# Altium schematic sheet LED_Drivers.SchDoc(LED_Drivers)
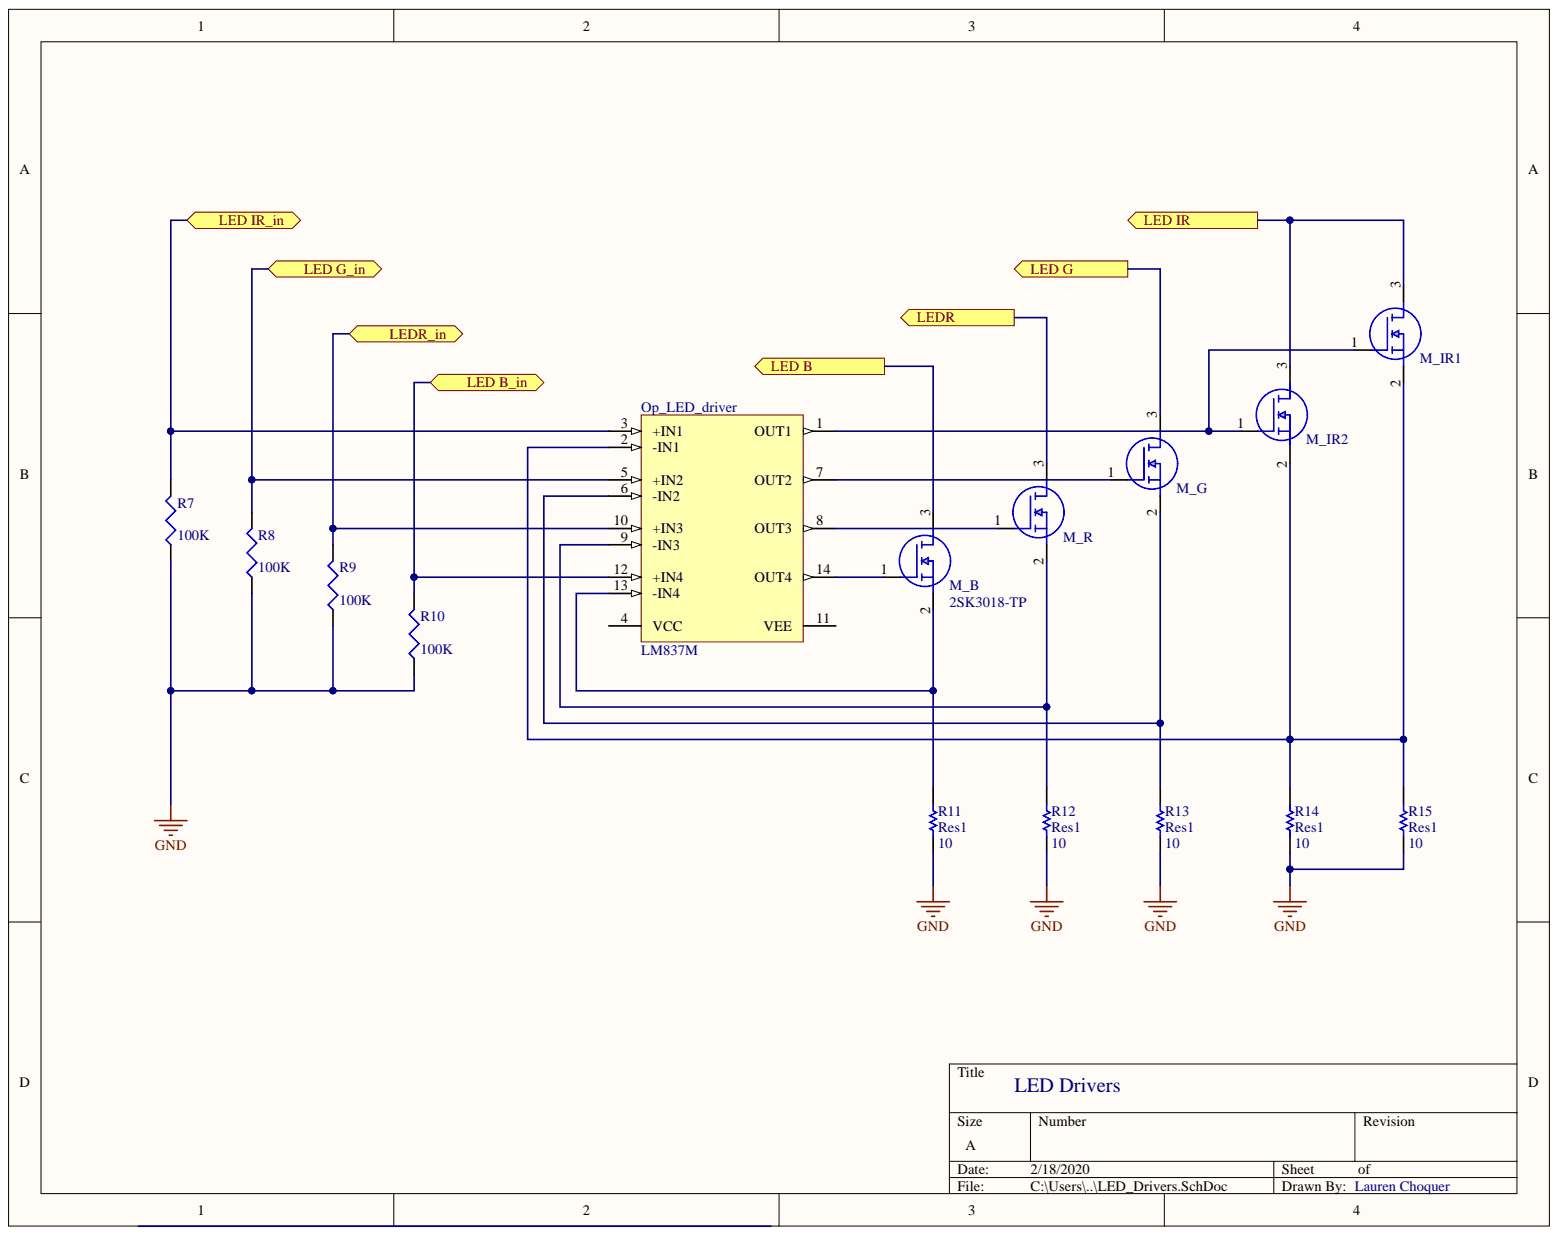
[diagram p0: LED_Drivers.SchDoc]

## Parts
M_B  2SK3018-TP  [2SK3018TP]
M_G  2SK3018-TP  [2SK3018TP]
M_IR1  2SK3018-TP  [2SK3018TP]
M_IR2  2SK3018-TP  [2SK3018TP]
M_R  2SK3018-TP  [2SK3018TP]
Op_LED_driver  LM837M  [D0014A_M]
R7  value 100K  [J1-0603]  (hidden comment: Res3)
R8  value 100K  [J1-0603]  (hidden comment: Res3)
R9  value 100K  [J1-0603]  (hidden comment: Res3)
R10  value 100K  [J1-0603]  (hidden comment: Res3)
R11  Res1  value 10  [AXIAL-0.3]
R12  Res1  value 10  [AXIAL-0.3]
R13  Res1  value 10  [AXIAL-0.3]
R14  Res1  value 10  [AXIAL-0.3]
R15  Res1  value 10  [AXIAL-0.3]

## Ports
LED B, LED B_in, LED G, LED G_in, LED IR, LED IR_in, LEDR, LEDR_in

## Nets
GND: R7.1 R8.1 R9.1 R10.1 R11.1 R12.1 R13.1 R14.1 R15.1
LED B: M_B.3 ; port LED B
LED B_in: Op_LED_driver.12(+IN4) R10.2 ; port LED B_in
LED G: M_G.3 ; port LED G
LED G_in: Op_LED_driver.5(+IN2) R8.2 ; port LED G_in
LED IR: M_IR1.3 M_IR2.3 ; port LED IR
LED IR_in: Op_LED_driver.3(+IN1) R7.2 ; port LED IR_in
LEDR: M_R.3 ; port LEDR
LEDR_in: Op_LED_driver.10(+IN3) R9.2 ; port LEDR_in
NetM_B_1: M_B.1 Op_LED_driver.14(OUT4)
NetM_B_2: M_B.2 Op_LED_driver.13(-IN4) R11.2
NetM_G_1: M_G.1 Op_LED_driver.7(OUT2)
NetM_G_2: M_G.2 Op_LED_driver.6(-IN2) R13.2
NetM_IR1_1: M_IR1.1 M_IR2.1 Op_LED_driver.1(OUT1)
NetM_IR1_2: M_IR1.2 M_IR2.2 Op_LED_driver.2(-IN1) R14.2 R15.2
NetM_R_1: M_R.1 Op_LED_driver.8(OUT3)
NetM_R_2: M_R.2 Op_LED_driver.9(-IN3) R12.2
N00028:

Not connected: Op_LED_driver 4(VCC) 11(VEE)

## Library data of the parts
M_B M_G M_IR1 M_IR2 M_R = 2SK3018-TP: MOSFET N-Channel MOSFET, SOT-323 package; Manufacturer_Name: Micro Commercial Components (MCC); Geometry.Height: 1.1mm; Mouser Price/Stock: https://www.mouser.com/Search/Refine.aspx?Keyword=833-2SK3018-TP; Mouser Part Number: 833-2SK3018-TP; Manufacturer_Part_Number: 2SK3018-TP; Library Name: 2SK3018-TP.SchLib; Datasheet Link: https://www.mccsemi.com/pdf/Products/2SK3018(SOT-323).pdf; Component Kind: Standard
Op_LED_driver = CMP-0057-00361-3: Low Noise Quad Operational Amplifier, 14-pin Narrow SOIC; Manufacturer: Texas Instruments; PowerWise: Y; PackageTopMarking: NSUZXYTTE#*LM837M; CompType: Audio IC; RoHS: N; PinCount: 14; PackageReference: D0014A; PackageDescription: Molded Package S.O., .150in Wide, 14 Lead; Library Name: Altium Content Vault; ComponentLink1URL: http://www.ti.com/general/docs/lit/getliterature.tsp?genericPartNumber=LM837&fileType=pdf; ComponentLink1Description: Datasheet URL; Component Kind: Standard; Code_JEDEC: MS-012AB; Code_IPC: SOIC127P600-14
R7-R10 = Res3: Resistor; Simulation: RESISTOR; Signal Integrity: Res; Published: 8-Jun-2000; PackageReference: J1-0603; PackageDescription: Chip Resistor; Library Name: Miscellaneous Devices.IntLib; LatestRevisionNote: IPC-7351 Footprint Added.; LatestRevisionDate: 29-May-2009; Component Kind: Standard; Publisher: Altium Limited
R11-R15 = Res1: Resistor; Simulation: RESISTOR; Signal Integrity: Res; Published: 8-Jun-2000; PackageReference: AXIAL-0.3; PackageDescription: Axial Device, Thru-Hole; 2 Leads; 0.3 in Pin Spacing; Library Name: Miscellaneous Devices.IntLib; LatestRevisionNote: Re-released for DXP Platform.; LatestRevisionDate: 17-Jul-2002; Component Kind: Standard; Publisher: Altium Limited
